# Altium schematic sheet GPS.SchDoc(GPS)
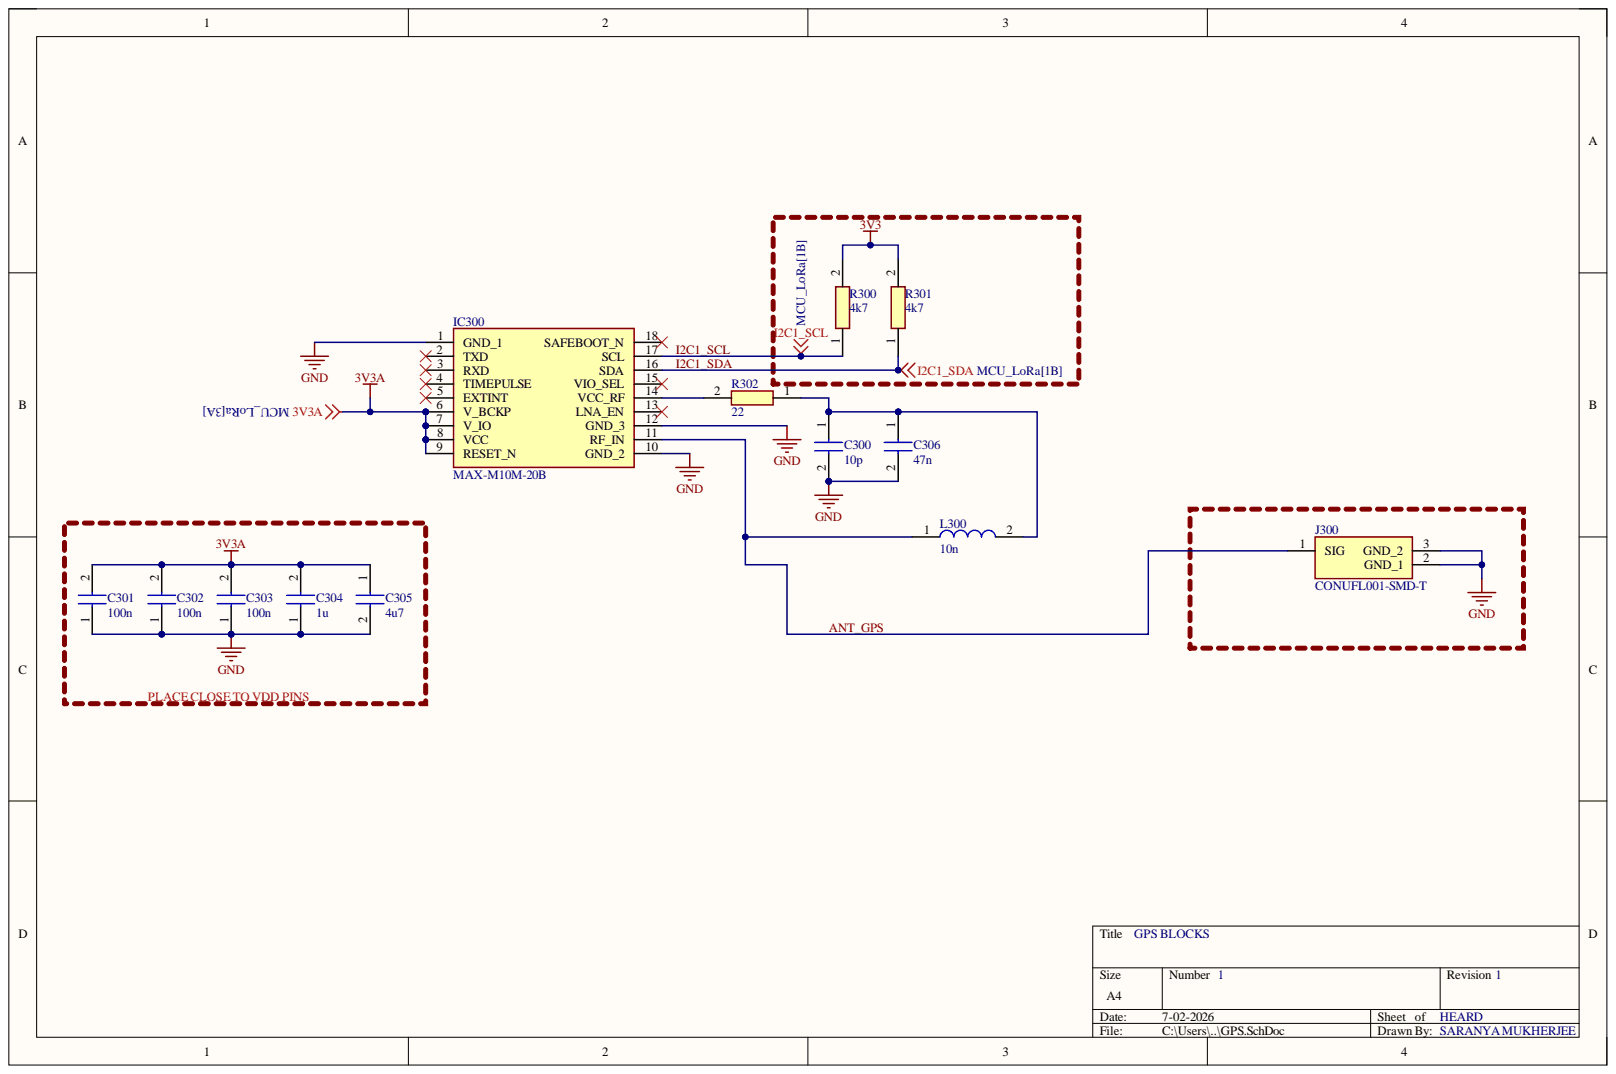
[diagram p0: GPS.SchDoc]

## Parts
C300  10p  [CAPC0402X22N]
C301  100n  [CAPC0402X22N]
C302  100n  [CAPC0402X22N]
C303  100n  [CAPC0402X22N]
C304  1u  [CAPC0402X22N]
C305  4u7  [GRM033D70J224ME01D]
C306  47n  [CAPC0402X22N]
IC300  MAX-M10M-20B  [MAXM10M20B]
J300  CONUFL001-SMD-T  [CONUFL001SMDT]  (value not drawn)
L300  10n  [LQW15DN100M00D]
R300  4k7  [RESC1005X40N]
R301  4k7  [RESC1005X40N]
R302  22  [RESC1005X40N]

## Nets
3V3A: C301.2 C302.2 C303.2 C304.2 C305.1 IC300.6(V_BCKP) IC300.7(V_IO) IC300.8(VCC) IC300.9(RESET_N)
+3.3V: R300.2 R301.2
GND: C300.2 C301.1 C302.1 C303.1 C304.1 C305.2 C306.2 IC300.1(GND_1) IC300.10(GND_2) IC300.12(GND_3) J300.2 J300.3
ANT_GPS: IC300.11(RF_IN) J300.1 L300.1
I2C1_SCL: IC300.17(SCL) R300.1
I2C1_SDA: IC300.16(SDA) R301.1
NetC300_1: C300.1 C306.1 L300.2 R302.1
NetIC300_14: IC300.14(VCC_RF) R302.2

Not connected: IC300 2(TXD) 3(RXD) 4(TIMEPULSE) 5(EXTINT) 13(LNA_EN) 15(VIO_SEL) 18(SAFEBOOT_N)

## Library data of the parts
C300-C306 = C0402X7R0G101K020BC: Capacitor; Manufacturer_Name: TDK; Component Kind: Standard; Datasheet Link: https://product.tdk.com/system/files/dam/doc/product/capacitor/ceramic/mlcc/catalog/mlcc_commercial_general_en.pdf; Height: 0.22mm; Manufacturer_Part_Number: C0402X7R0G101K020BC
IC300 = MAX-M10M-20B: Integrated Circuit; Manufacturer_Name: u-blox; Component Kind: Standard; Datasheet Link: https://content.u-blox.com/sites/default/files/documents/MAX-M10M-20B_DataSheet_UBXDOC-963802114-13063.pdf; Height: 2.7mm; Manufacturer_Part_Number: MAX-M10M-20B
J300 = CONUFL001-SMD-T: Connector; Manufacturer_Name: TE Connectivity; Arrow Part Number: CONUFL001-SMD-T; Arrow Price/Stock: https://www.arrow.com/en/products/conufl001-smd-t/te-connectivity?utm_currency=USD&region=nac; Component Kind: Standard; Datasheet Link: https://eu.mouser.com/datasheet/2/418/9/ENG_DS_CONUFL001_SMD_T_B-3238717.pdf; Height: 1.3mm; Manufacturer_Part_Number: CONUFL001-SMD-T; Mouser Part Number: N/A; Mouser Price/Stock: https://www.mouser.co.uk/ProductDetail/TE-Connectivity/CONUFL001-SMD-T?qs=3Rah4i%252BhyCHmRzMH%252BkLkPQ%3D%3D
L300 = LQW15DN150M00D: Inductor; Manufacturer_Name: Murata Electronics; Component Kind: Standard; Datasheet Link: https://www.digikey.com/en/products/detail/murata-electronics/LQW15DN150M00D/9559544; Height: 0.7mm; Manufacturer_Part_Number: LQW15DN150M00D; Mouser Part Number: 81-LQW15DN150M00D; Mouser Price/Stock: https://www.mouser.co.uk/ProductDetail/Murata-Electronics/LQW15DN150M00D?qs=55YtniHzbhAjiaw66ArnFg%3D%3D
R300-R302 = RC0402JR-070RL: Resistor; Manufacturer_Name: Yageo Group; Arrow Part Number: RC0402JR-070RL; Arrow Price/Stock: https://www.arrow.com/en/products/rc0402jr-070rl/yageo.html?utm_currency=USD&region=europe; Component Kind: Standard; Datasheet Link: https://www.yageo.com/upload/media/product/products/datasheet/rchip/PYu-RC_Group_51_RoHS_L_12.pdf; Height: 0.4mm; Manufacturer_Part_Number: RC0402JR-070RL; Mouser Part Number: 603-RC0402JR-070RL; Mouser Price/Stock: https://www.mouser.co.uk/ProductDetail/YAGEO/RC0402JR-070RL?qs=5Bml4Cp94iv7ykKkzPrwpQ%3D%3D
